# Altium schematic sheet Power.SchDoc(Power)
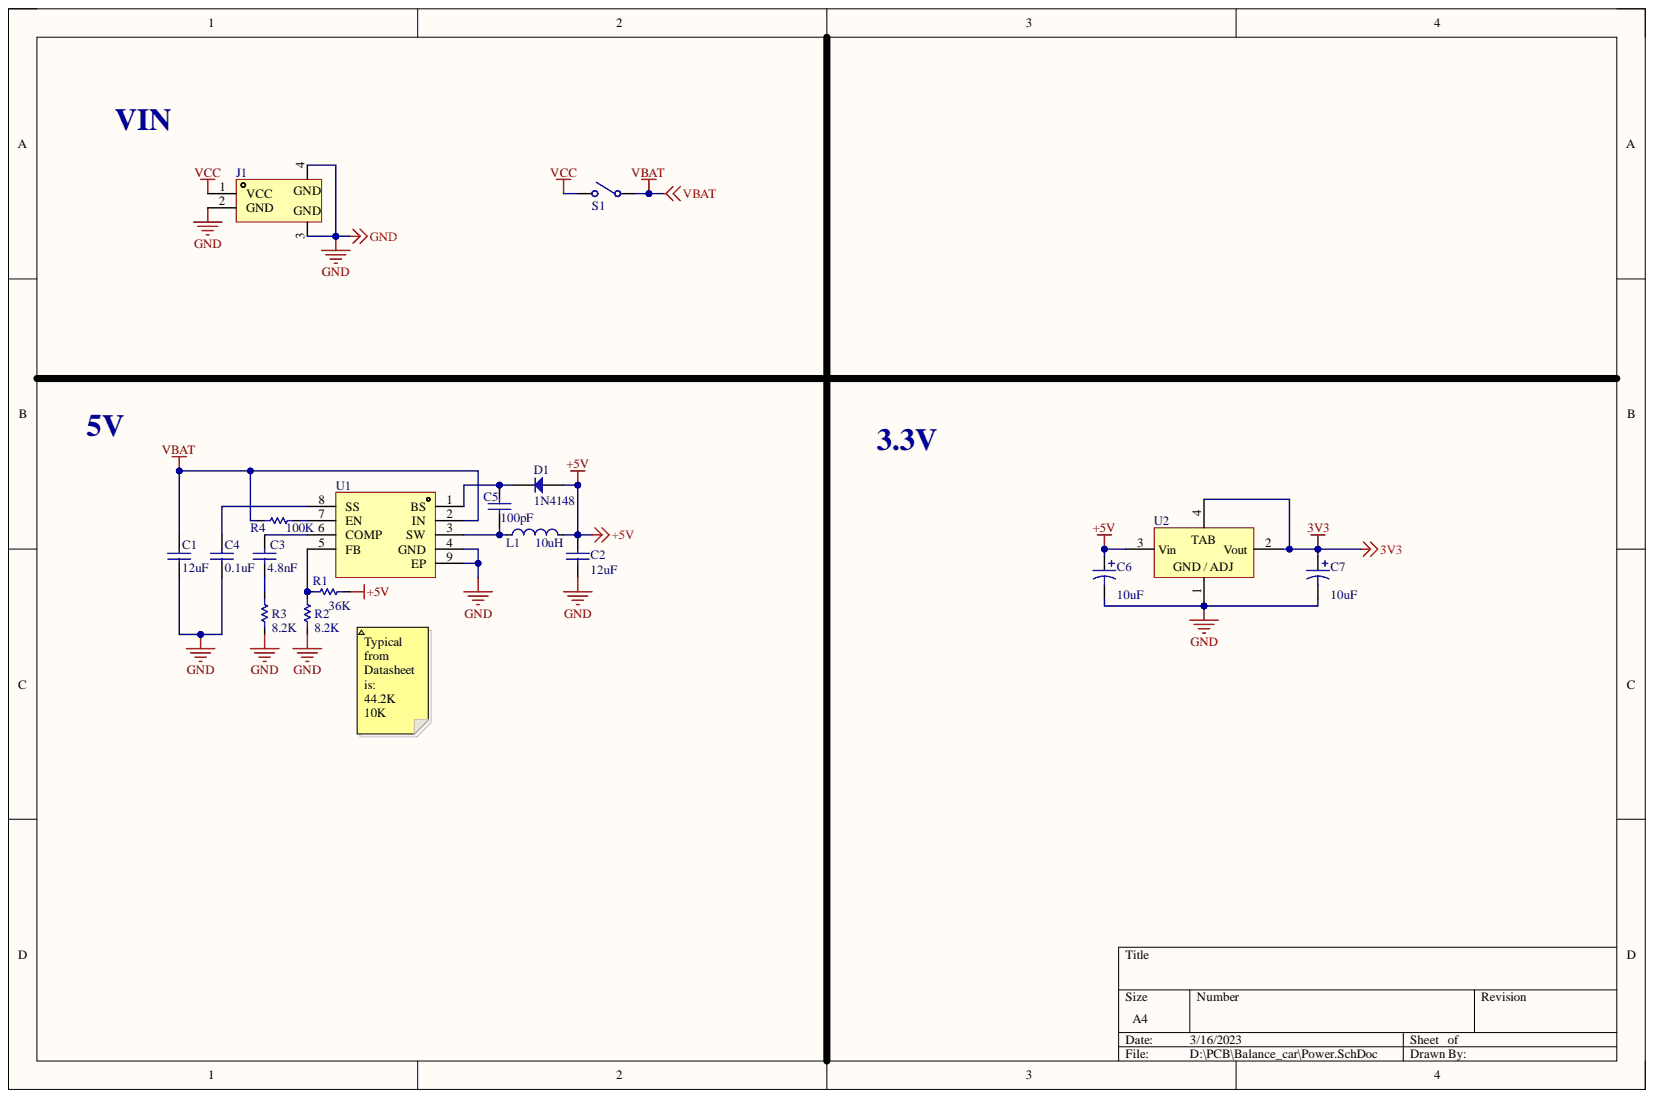
[diagram p0: Power.SchDoc]

## Parts
C1  value 12uF  [C1206]  (hidden comment: Cap)
C2  value 12uF  [C1206]  (hidden comment: Cap)
C3  value 4.8nF  [C0603]  (hidden comment: Cap)
C4  value 0.1uF  [C0603]  (hidden comment: Cap)
C5  value 100pF  [C0603]  (hidden comment: Cap)
C6  value 10uF  [CAP-SMD_BD4.0-L4.3-W4.3-FD-LS5.3]  (hidden comment: Cap Pol)
C7  value 10uF  [CAP-SMD_BD4.0-L4.3-W4.3-FD-LS5.3]  (hidden comment: Cap Pol)
D1  1N4148  [SOD-123F]
J1  XT60PW-M  [XT60PW-M]  (value not drawn)
L1  10uH  [IND-SMD_L7.3-W6.6]
R1  value 36K  [R0603]  (hidden comment: Res)
R2  value 8.2K  [R0603]  (hidden comment: Res)
R3  value 8.2K  [R0603]  (hidden comment: Res)
R4  value 100K  [R0603]  (hidden comment: Res)
S1  SW-PB  [SW-PB]  (value not drawn)
U1  MP2307  [MP2307]  (value not drawn)
U2  1117  [SOT-223-3]  (value not drawn)

## Nets
3V3: C7.1 U2.2(Vout) U2.4
+5V: C2.1 C6.1 D1.2 L1.2 R1.1 U2.3(Vin)
GND: C1.1 C2.2 C4.1 C6.2 C7.2 J1.2(GND) J1.3 J1.4 R2.1 R3.1 U1.4(GND) U1.9(EP) U2.1
VBAT: C1.2 R4.1 S1.1 U1.2(IN)
VCC: J1.1(VCC) S1.2
NetC3_1: C3.1 R3.2
NetC3_2: C3.2 U1.6(COMP)
NetC4_2: C4.2 U1.8(SS)
NetC5_1: C5.1 L1.1 U1.3(SW)
NetC5_2: C5.2 D1.1 U1.1(BS)
NetR1_2: R1.2 R2.2 U1.5(FB)
NetR4_2: R4.2 U1.7(EN)

## Library data of the parts
C1-C5 = Cap: Capacitor; Component Kind: Standard; LatestRevisionDate: 17-Jul-2002; LatestRevisionNote: Re-released for DXP Platform.; PackageDescription: Radial Cap, Thru-Hole; 2 Leads; 0.3 in Pin Spacing; PackageReference: RAD-0.3; Published: 8-Jun-2000; Signal Integrity: Cap; Simulation: CAP; Library Name: Component footprints.IntLib; Publisher: Altium Limited
C6 C7 = Cap Pol: Polarized Capacitor (Axial); Component Kind: Standard; LatestRevisionDate: 17-Jul-2002; LatestRevisionNote: Re-released for DXP Platform.; PackageDescription: Polarized Capacitor; 2 Leads; PackageReference: POLAR0.8; Published: 8-Jun-2000; Signal Integrity: Cap Pol; Simulation: CAP; Library Name: Component footprints.IntLib; Publisher: Altium Limited
D1 = 1N4148: High Conductance Fast Diode; Code_JEDEC: DO-204-AH; Component Kind: Standard; ComponentLink1Description: Manufacturer Link; ComponentLink1URL: http://www.fairchildsemi.com/; ComponentLink2Description: Datasheet; ComponentLink2URL: http://www.fairchildsemi.com/ds/1N%2F1N4148.pdf; DatasheetVersion: 1997; LatestRevisionDate: 17-Jul-2002; LatestRevisionNote: Re-released for DXP Platform.; PackageDescription: Diode, Axial; Body 3.8 x 1.9 mm (LxDia. max), Lead Dia. 0.53 mm (max); PackageReference: DO-35; Published: 8-Jun-2000; Library Name: Component footprints.IntLib; Publisher: Altium Limited
L1 = IND-SMD_L7.3-W6.6: 电感值:10uH;精度:±20%;额定电流:4A;饱和电流(Isat):5.5A;直流电阻(DCR):62mΩ;; Manufacturer: PSA(信昌电陶); Add into BOM: yes; DC Resistance (DCR): 62mΩ; Device: MCS0630-100MPY1; Rated Current: 4A; Saturation Current (Isat): 5.5A; Tolerance: ±20%; Component Kind: Standard; Convert to PCB: yes; Datasheet: https://atta.szlcsc.com/upload/public/pdf/source/20220121/EA6E6E6F70ED7BAE67EC293805EAAACB.pdf; JLCPCB Part Class: Extended Part; LCSC Part Name: 10uH ±20% 4A 62mΩ; Manufacturer Part: MCS0630-100MPY1; Name: 10uH; PCB3D: IND-SMD_L7.1-W6.6-H2.8; Supplier: LCSC; Supplier Footprint: 0630; Supplier Part: C2937094; Symbol: MCS0630-100MPY1; Value: 10uH; Library Name: Component footprints.IntLib
R1-R4 = Res: Resistor; Component Kind: Standard; LatestRevisionDate: 17-Jul-2002; LatestRevisionNote: Re-released for DXP Platform.; PackageDescription: Axial Device, Thru-Hole; 2 Leads; 0.3 in Pin Spacing; PackageReference: AXIAL-0.3; Published: 8-Jun-2000; Signal Integrity: Res; Simulation: RESISTOR; Library Name: Component footprints.IntLib; Publisher: Altium Limited
S1 = SW-PB: 船型开关; Component Kind: Standard; LatestRevisionDate: 17-Jul-2002; LatestRevisionNote: Re-released for DXP Platform.; Library Name: Common_Modules.IntLib; PackageDescription: Switch; 2 Leads; PackageReference: SPST-2; Published: 24-Mar-1999; Publisher: Altium Limited
J1 = XT60PW-M: Component Kind: Standard; Library Name: Connectivity_Plugs.IntLib
U1 = MP2307: Component Kind: Standard; Library Name: Power_Module.IntLib
U2 = 1117: Component Kind: Standard; Library Name: Power_Module.IntLib
